# Altium schematic sheet Sheet6.SchDoc
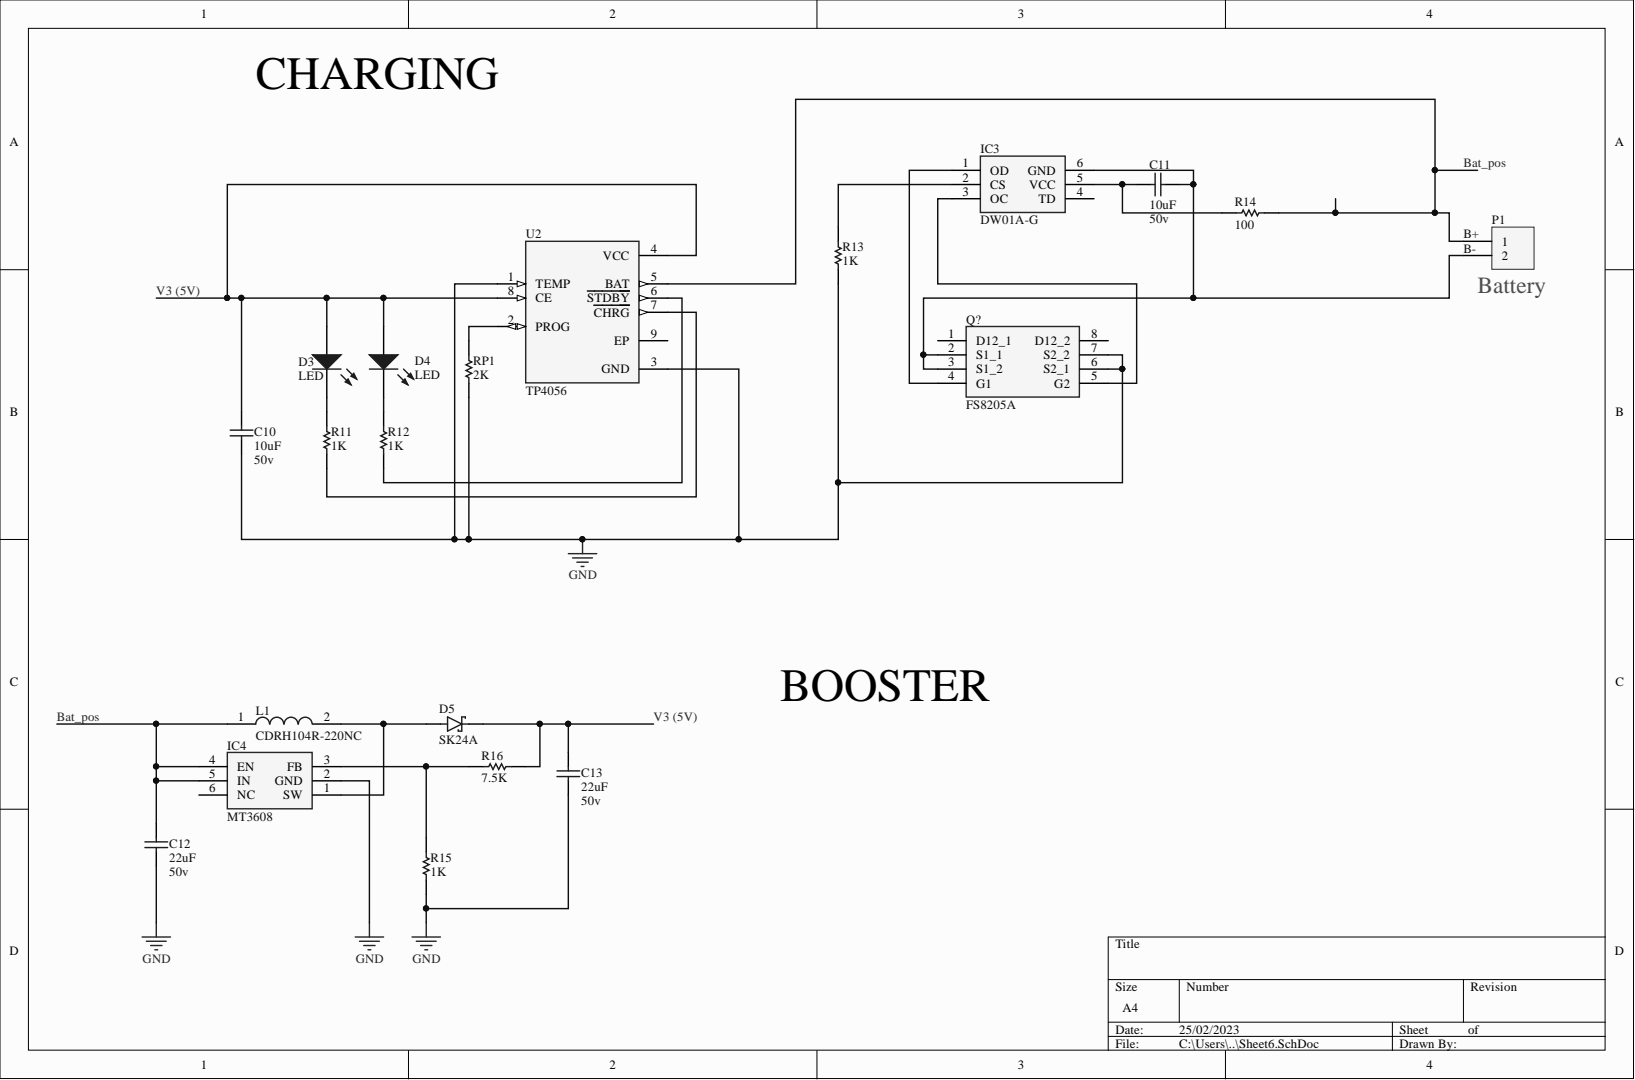
[diagram p0: Sheet6.SchDoc]

## Parts
C10  10uF  Volte: 50v  [MY_0603]
C11  value 10uF  Volte: 50v  [MY_0603]  (hidden comment: 100nF)
C12  22uF  Volte: 50v  [MY_0603]
C13  22uF  Volte: 50v  [MY_0603]
D3  LED  [MY_LED_0805]
D4  LED  [MY_LED_0805]
D5  SK24A  [DIOM4325X250N]
IC3  DW01A-G  [SOT95P190X145-6N]
IC4  MT3608  [SOT95P280X145-6N]
L1  CDRH104R-220NC  [INDPM102100X400N]
P1  Header 2  [MY_6410-02]  (value not drawn)
Q?  FS8205A  [SOP65P640X120-8N]
R11  1K  [MY_0603]
R12  1K  [MY_0603]
R13  1K  [MY_0603]
R14  100  [MY_0603]
R15  1K  [MY_0603]
R16  7.5K  [MY_0603]
RP1  2K  [MY_0603]
U2  TP4056  [SOP127P600X175-9N]

## Nets
GND: C10.1 C12.1 C13.1 IC4.2(GND) Q?.6(S2_1) Q?.7(S2_2) R13.2 R15.2 RP1.2 U2.1(TEMP) U2.3(GND)
Bat_pos: C12.2 IC4.4(EN) IC4.5(IN) L1.1 P1.1 R14.2 U2.5(BAT)
V3 (5V): C10.2 C13.2 D3.A D4.A D5.C R16.2 U2.4(VCC) U2.8(CE)
NetC11_1: C11.1 IC3.6(GND) P1.2 Q?.2(S1_1) Q?.3(S1_2)
NetC11_2: C11.2 IC3.5(VCC) R14.1
NetD3_K: D3.K R11.1
NetD4_K: D4.K R12.1
NetD5_A: D5.A IC4.1(SW) L1.2
NetIC3_1: IC3.1(OD) Q?.4(G1)
NetIC3_2: IC3.2(CS) R13.1
NetIC3_3: IC3.3(OC) Q?.5(G2)
NetIC4_3: IC4.3(FB) R15.1 R16.1
NetR11_2: R11.2 U2.7(CHRG)
NetR12_2: R12.2 U2.6(STDBY)
NetRP1_1: RP1.1 U2.2(PROG)

Not connected: IC3 4(TD); IC4 6(NC); Q? 1(D12_1) 8(D12_2); U2 9(EP)

## Library data of the parts
C10-C13 = Cap ceramic: None polar capacitor; ASiD Part Number: C-AS; Component Kind: Standard; Library Name: Mylib+Rev+4.IntLib
  C10: Value: 10uF
  C12 C13: Value: 22uF
D3 D4 = LED: Typical INFRARED GaAs LED; ASiD Part Number: LED-AS; Component Kind: Standard; Library Name: Mylib+Rev+4.IntLib
D5 = SK24A: 2A, 40V, Schottky Rectifier; MF: Taiwan Semiconductor; Availability: In Stock; Check_prices: https://www.snapeda.com/parts/SK24A/Taiwan+Semiconductor/view-part/?ref=eda; Component Kind: Standard; Library Name: SK24A.IntLib; MP: SK24A; Package: SMA Taiwan Semiconductor; Price: None; SnapEDA_Link: https://www.snapeda.com/parts/SK24A/Taiwan+Semiconductor/view-part/?ref=snap
IC3 = DW01A-G: Integrated Circuit; Manufacturer_Name: SII Semiconductor; Component Kind: Standard; Datasheet Link: http://www.ic-fortune.com/upload/Download/DW01x-DS-17_EN.pdf; Height: 1.45mm; Manufacturer_Part_Number: DW01A-G
IC4 = MT3608: Integrated Circuit; Manufacturer_Name: Aerosemi; Component Kind: Standard; Datasheet Link: https://www.olimex.com/Products/Breadboarding/BB-PWR-3608/resources/MT3608.pdf; Height: 1.45mm; Manufacturer_Part_Number: MT3608
L1 = CDRH104R-220NC: Inductor; Manufacturer_Name: Sumida; Component Kind: Standard; Datasheet Link: https://media.digikey.com/pdf/Data%20Sheets/Sumida%20PDFs/CDRH104R%20S0746082%20(2).pdf; Height: 4mm; Manufacturer_Part_Number: CDRH104R-220NC; Mouser Part Number: N/A; Mouser Price/Stock: https://www.mouser.co.uk/ProductDetail/Sumida/CDRH104R-220NC?qs=GoGuFahitro%252BvUlRr8hvsw%3D%3D
P1 = Header 2: Header, 2-Pin; ASiD Part Number: Header 2-AS; Component Kind: Standard; Library Name: Mylib+Rev+4.IntLib; Signal Integrity: Connector
Q? = FS8205A: MOSFET (N-Channel); Manufacturer_Name: FSC; Component Kind: Standard; Datasheet Link: https://datasheet.lcsc.com/szlcsc/Fortune-Semicon-FS8205A_C16052.pdf; Height: 1.2mm; Manufacturer_Part_Number: FS8205A
R11-R16 RP1 = Res: Standard resistor; ASiD Part Number: Res-AS; Component Kind: Standard; Library Name: Mylib+Rev+4.IntLib
  R11-R13 R15: Value: 1K
  R14: Value: 100
  R16: Value: 7.5K
  RP1: Value: 2K
U2 = TP4056: Complete single cell Li-Ion battery with a constant current / constant voltage linear charger; MF: NanJing Top Power ASIC Corp.; Availability: Not in stock; Check_prices: https://www.snapeda.com/parts/TP4056/NanJing+Top+Power+ASIC+Corp./view-part/?ref=eda; Component Kind: Standard; Library Name: TP4056.IntLib; MP: TP4056; Package: Package; Price: None; SnapEDA_Link: https://www.snapeda.com/parts/TP4056/NanJing+Top+Power+ASIC+Corp./view-part/?ref=snap
